# Altium schematic sheet Sheet1.SchDoc
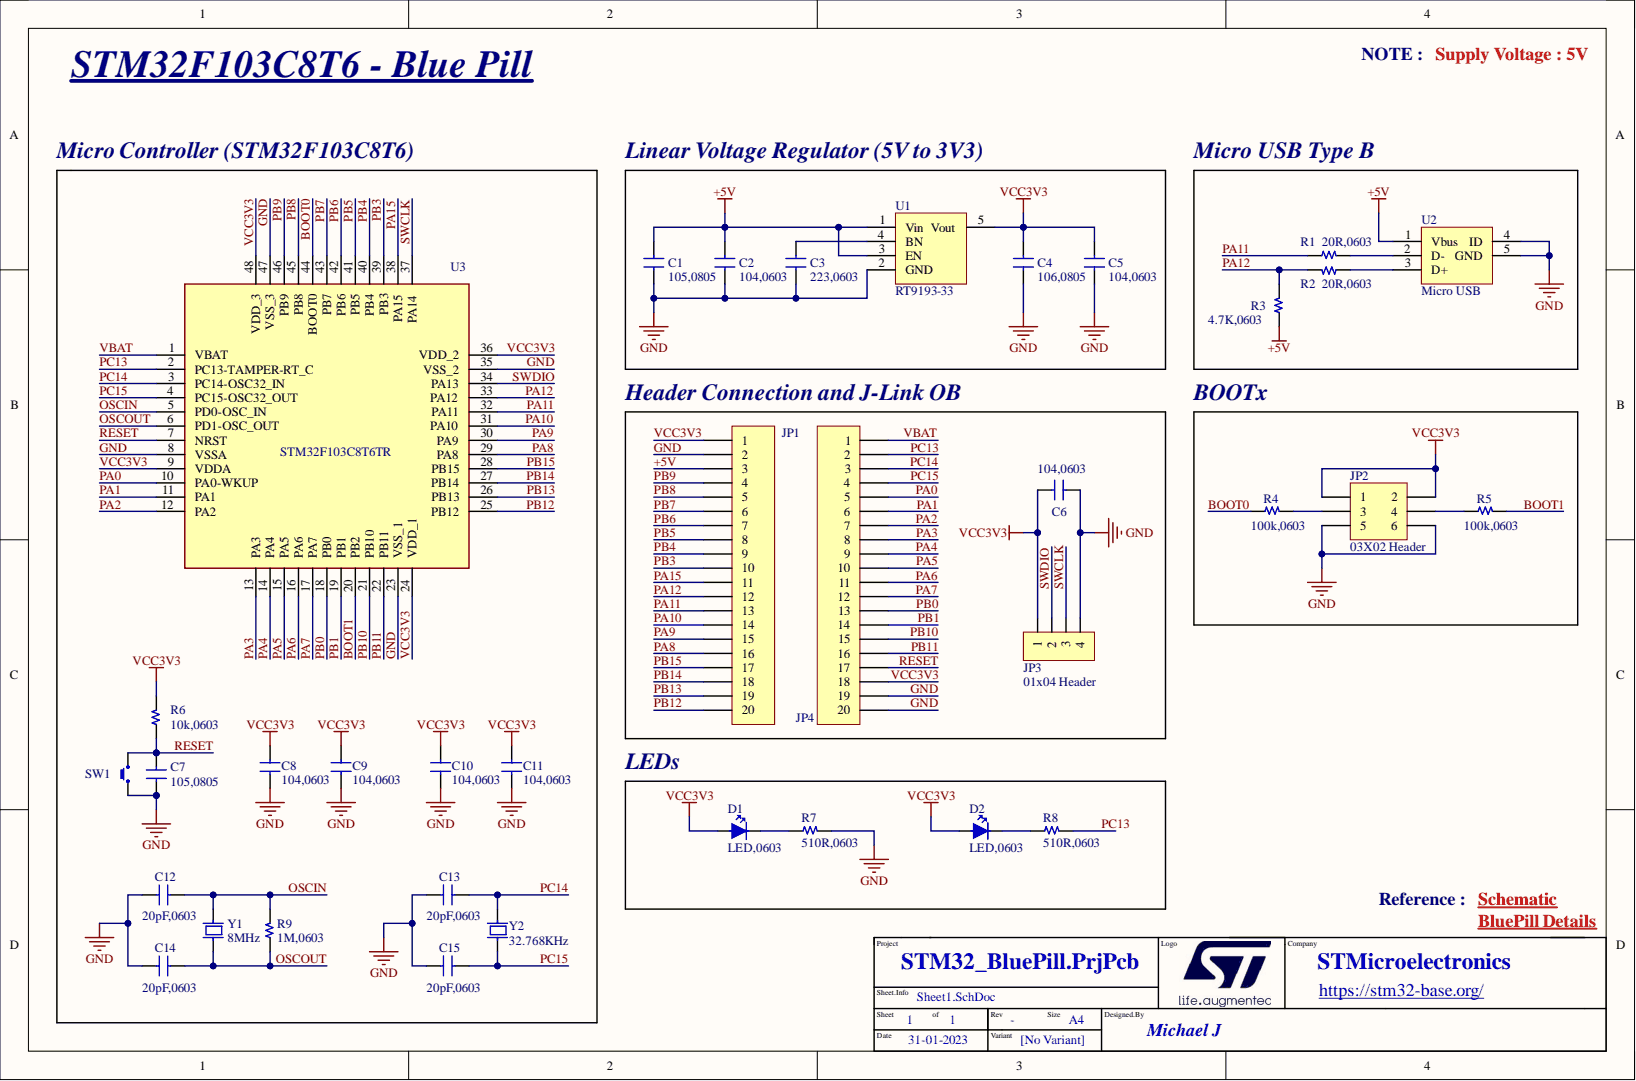
[diagram p0: Sheet1.SchDoc]

## Parts
C1  105,0805  [Capacitor,0805]
C2  104,0603  [Capacitor,0603]
C3  223,0603  [Capacitor,0603]
C4  106,0805  [Capacitor,0805]
C5  104,0603  [Capacitor,0603]
C6  104,0603  [Capacitor,0603]
C7  105,0805  [Capacitor,0805]
C8  104,0603  [Capacitor,0603]
C9  104,0603  [Capacitor,0603]
C10  104,0603  [Capacitor,0603]
C11  104,0603  [Capacitor,0603]
C12  20pF,0603  [Capacitor,0603]
C13  20pF,0603  [Capacitor,0603]
C14  20pF,0603  [Capacitor,0603]
C15  20pF,0603  [Capacitor,0603]
D1  LED,0603  [LED,0603]
D2  LED,0603  [LED,0603]
JP1  01x20 Header  [01x20 Header]  (value not drawn)
JP2  03X02 Header  [03x02 Header]
JP3  01x04 Header  [01x04 Header]
JP4  01x20 Header  [01x20 Header]  (value not drawn)
R1  20R,0603  [Resistor,0603]
R2  20R,0603  [Resistor,0603]
R3  4.7K,0603  [Resistor,0603]
R4  100k,0603  [Resistor,0603]
R5  100k,0603  [Resistor,0603]
R6  10k,0603  [Resistor,0603]
R7  510R,0603  [Resistor,0603]
R8  510R,0603  [Resistor,0603]
R9  1M,0603  [Resistor,0603]
SW1  Tactile Switch  [SMD Tactile Switch, 3x6x2.5mm]  (value not drawn)
U1  RT9193-33  [RT9193-33]
U2  Micro USB  [Micro USB]
U3  STM32F103C8T6TR  [STM32]
Y1  8MHz  [8MHz Crystal]
Y2  32.768KHz  [32.768KHz Crystal]

## Nets
+5V: C1.2 C2.2 JP1.3 R3.1 U1.1(Vin) U1.3(EN) U2.1(Vbus)
BOOT0: R4.1 U3.44(BOOT0)
BOOT1: R5.2 U3.20(PB2)
GND: C1.1 C2.1 C3.1 C4.1 C5.1 C6.1 C7.1 C8.1 C9.1 C10.1 C11.1 C12.1 C13.1 C14.1 C15.1 JP1.2 JP2.5 JP2.6 JP3.4 JP4.19 JP4.20 R7.2 SW1.1 U1.2(GND) U2.4(ID) U2.5(GND) U3.8(VSSA) U3.23(VSS_1) U3.35(VSS_2) U3.47(VSS_3)
OSCIN: C12.2 R9.2 U3.5(PD0-OSC_IN) Y1.2
OSCOUT: C14.2 R9.1 U3.6(PD1-OSC_OUT) Y1.1
PA0: JP4.5 U3.10(PA0-WKUP)
PA1: JP4.6 U3.11(PA1)
PA2: JP4.7 U3.12(PA2)
PA3: JP4.8 U3.13(PA3)
PA4: JP4.9 U3.14(PA4)
PA5: JP4.10 U3.15(PA5)
PA6: JP4.11 U3.16(PA6)
PA7: JP4.12 U3.17(PA7)
PA8: JP1.16 U3.29(PA8)
PA9: JP1.15 U3.30(PA9)
PA10: JP1.14 U3.31(PA10)
PA11: JP1.13 R1.1 U3.32(PA11)
PA12: JP1.12 R2.2 R3.2 U3.33(PA12)
PA15: JP1.11 U3.38(PA15)
PB0: JP4.13 U3.18(PB0)
PB1: JP4.14 U3.19(PB1)
PB3: JP1.10 U3.39(PB3)
PB4: JP1.9 U3.40(PB4)
PB5: JP1.8 U3.41(PB5)
PB6: JP1.7 U3.42(PB6)
PB7: JP1.6 U3.43(PB7)
PB8: JP1.5 U3.45(PB8)
PB9: JP1.4 U3.46(PB9)
PB10: JP4.15 U3.21(PB10)
PB11: JP4.16 U3.22(PB11)
PB12: JP1.20 U3.25(PB12)
PB13: JP1.19 U3.26(PB13)
PB14: JP1.18 U3.27(PB14)
PB15: JP1.17 U3.28(PB15)
PC13: JP4.2 R8.2 U3.2(PC13-TAMPER-RT_C)
PC14: C13.2 JP4.3 U3.3(PC14-OSC32_IN) Y2.2
PC15: C15.2 JP4.4 U3.4(PC15-OSC32_OUT) Y2.1
RESET: C7.2 JP4.17 R6.1 SW1.2 U3.7(NRST)
SWCLK: JP3.3 U3.37(PA14)
SWDIO: JP3.2 U3.34(PA13)
VBAT: JP4.1 U3.1(VBAT)
VCC3V3: C4.2 C5.2 C6.2 C8.2 C9.2 C10.2 C11.2 D1.1 D2.1 JP1.1 JP2.1 JP2.2 JP3.1 JP4.18 R6.2 U1.5(Vout) U3.9(VDDA) U3.24(VDD_1) U3.36(VDD_2) U3.48(VDD_3)
NetC3_2: C3.2 U1.4(BN)
NetD1_2: D1.2 R7.1
NetD2_2: D2.2 R8.1
NetJP2_3: JP2.3 R4.2
NetJP2_4: JP2.4 R5.1
NetR1_2: R1.2 U2.2(D-)
NetR2_1: R2.1 U2.3(D+)

## Library data of the parts
C1 C7 = 105,0805: 1uF; Component Kind: Standard; Library Name: Schlib.SchLib
C2 C5 C6 C8-C11 = 104,0603: 0.1uF; Component Kind: Standard; Library Name: Schlib.SchLib
C3 = 223,0603: 22nF; Component Kind: Standard; Library Name: Schlib.SchLib
C4 = 106,0805: 10uF; Component Kind: Standard; Library Name: Schlib.SchLib
C12-C15 = 20pF,0603: 20pF; Component Kind: Standard; Library Name: Schlib.SchLib
R1 R2 = 20R.0603: 20 Ohm; Component Kind: Standard; Library Name: Schlib.SchLib
R3 = 4.7K,0603: 4.7K Ohm; Component Kind: Standard; Library Name: Schlib.SchLib
R4-R6 = 100k,0603: 100K Ohm; Component Kind: Standard; Library Name: Schlib.SchLib
R7 R8 = 510R,0603: 510 Ohm; Component Kind: Standard; Library Name: Schlib.SchLib
R9 = 1M,0603: 1M Ohm; Component Kind: Standard; Library Name: Schlib.SchLib
SW1 = Tactile Switch: SMD Tactile Switch, 3x6x2.5mm model-4388; Component Kind: Standard; Library Name: Schlib.SchLib
U1 = RT9193-33: Linear Voltage Regulator; Component Kind: Standard; Library Name: Schlib.SchLib
U2 = Micro USB: Micro USB 10118192-0001LF; Manufacturer_Name: Digi-Key Electronics; Component Kind: Standard; Datasheet Link: https://datasheets.globalspec.com/pdf/viewpdf?partId={1242CD95-9A0C-4744-A41A-FA5CE849AF35}&vid=138662&comp=5843&from=detail; Library Name: Schlib.SchLib; Manufacturer_Part_Number: 10118192-0001LF
U3 = STM32F103C8T6TR: Integrated Circuit; Manufacturer_Name: STMicroelectronics; Arrow Part Number: STM32F103C8T6TR; Arrow Price/Stock: https://www.arrow.com/en/products/stm32f103c8t6tr/stmicroelectronics; Component Kind: Standard; Datasheet Link: http://media.digikey.com/pdf/Data%20Sheets/ST%20Microelectronics%20PDFS/STM32F103x8,B.pdf; Height: 1.6mm; Library Name: Schlib.SchLib; Manufacturer_Part_Number: STM32F103C8T6TR; Mouser Part Number: 511-STM32F103C8T6TR; Mouser Price/Stock: https://www.mouser.co.uk/ProductDetail/STMicroelectronics/STM32F103C8T6TR?qs=5HwTSiuA5HDxFj62n06yag%3D%3D
Y1 = 8MHz: Crystal; Component Kind: Standard; Library Name: Schlib.SchLib
Y2 = 32.768KHz: Crystal; Component Kind: Standard; Library Name: Schlib.SchLib
D1 D2 = LED,0603: Component Kind: Standard; Library Name: Schlib.SchLib
JP1 JP4 = 01x20 Header: Component Kind: Standard; Library Name: Schlib.SchLib
JP2 = 03x02 Header: Component Kind: Standard; Library Name: Schlib.SchLib
JP3 = 01x04 Header: Component Kind: Standard; Library Name: Schlib.SchLib
